# Altium schematic sheet Logic_controller.SchDoc(Logic_controller)
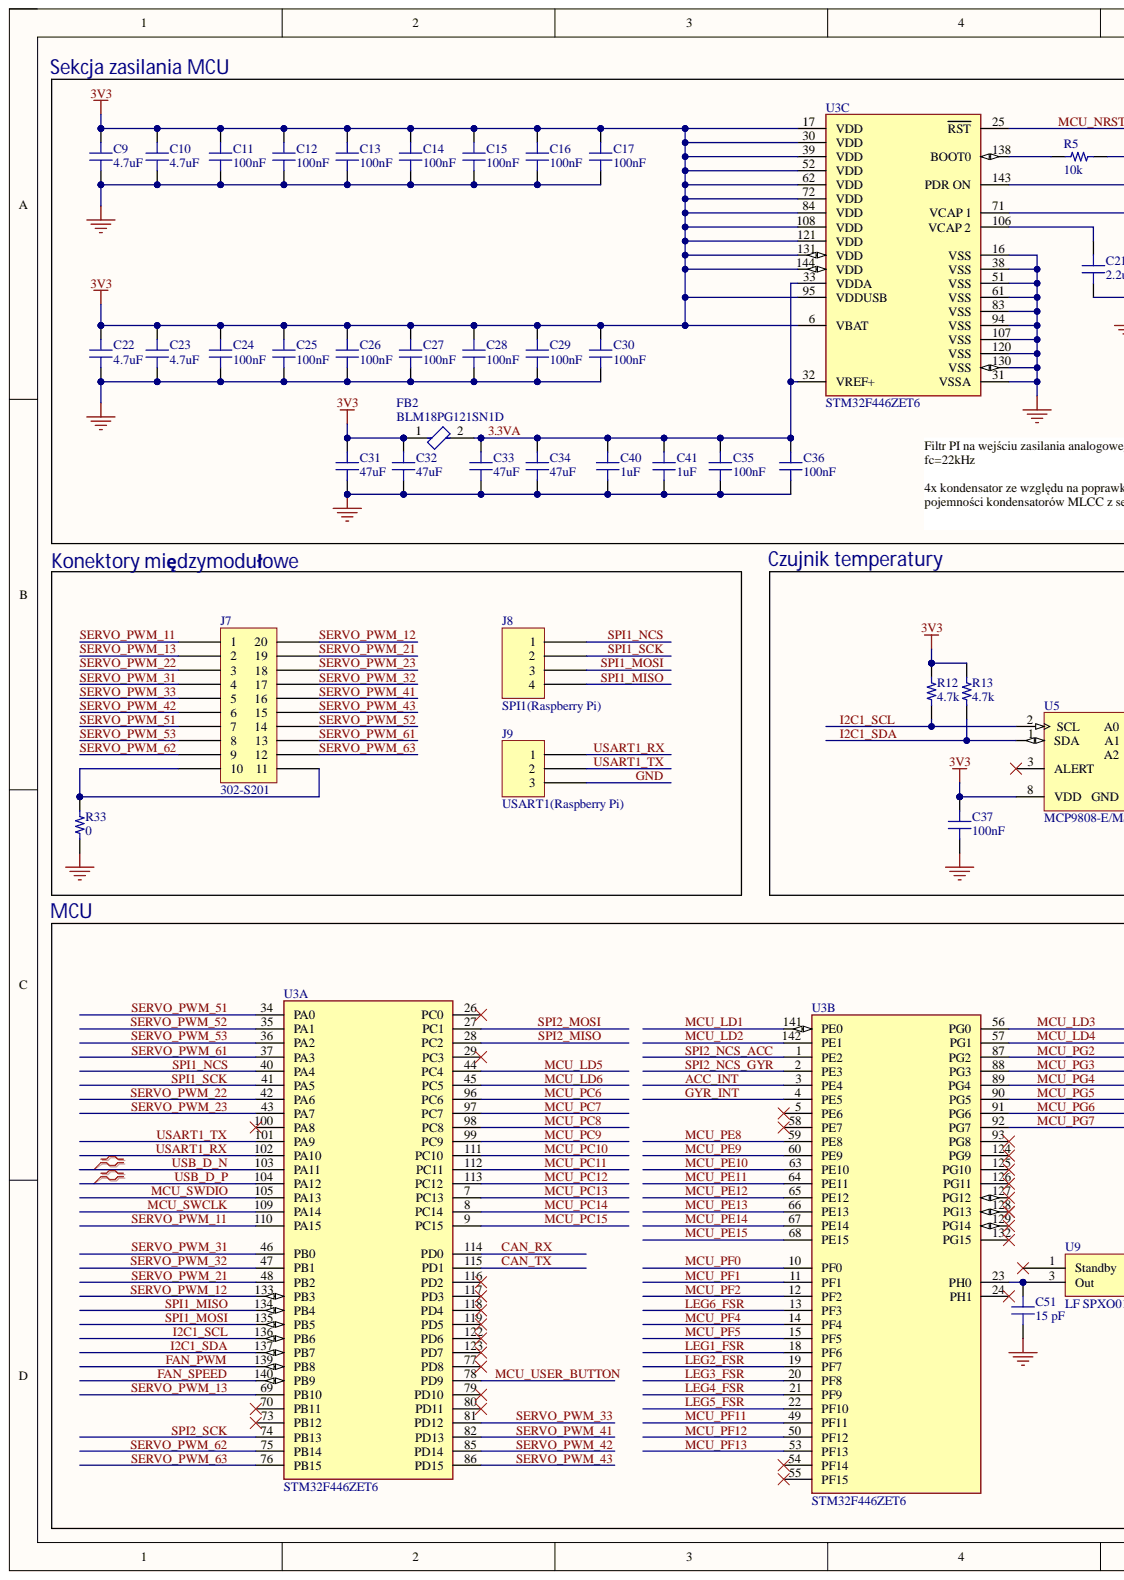
[diagram p0: Logic_controller.SchDoc, left]
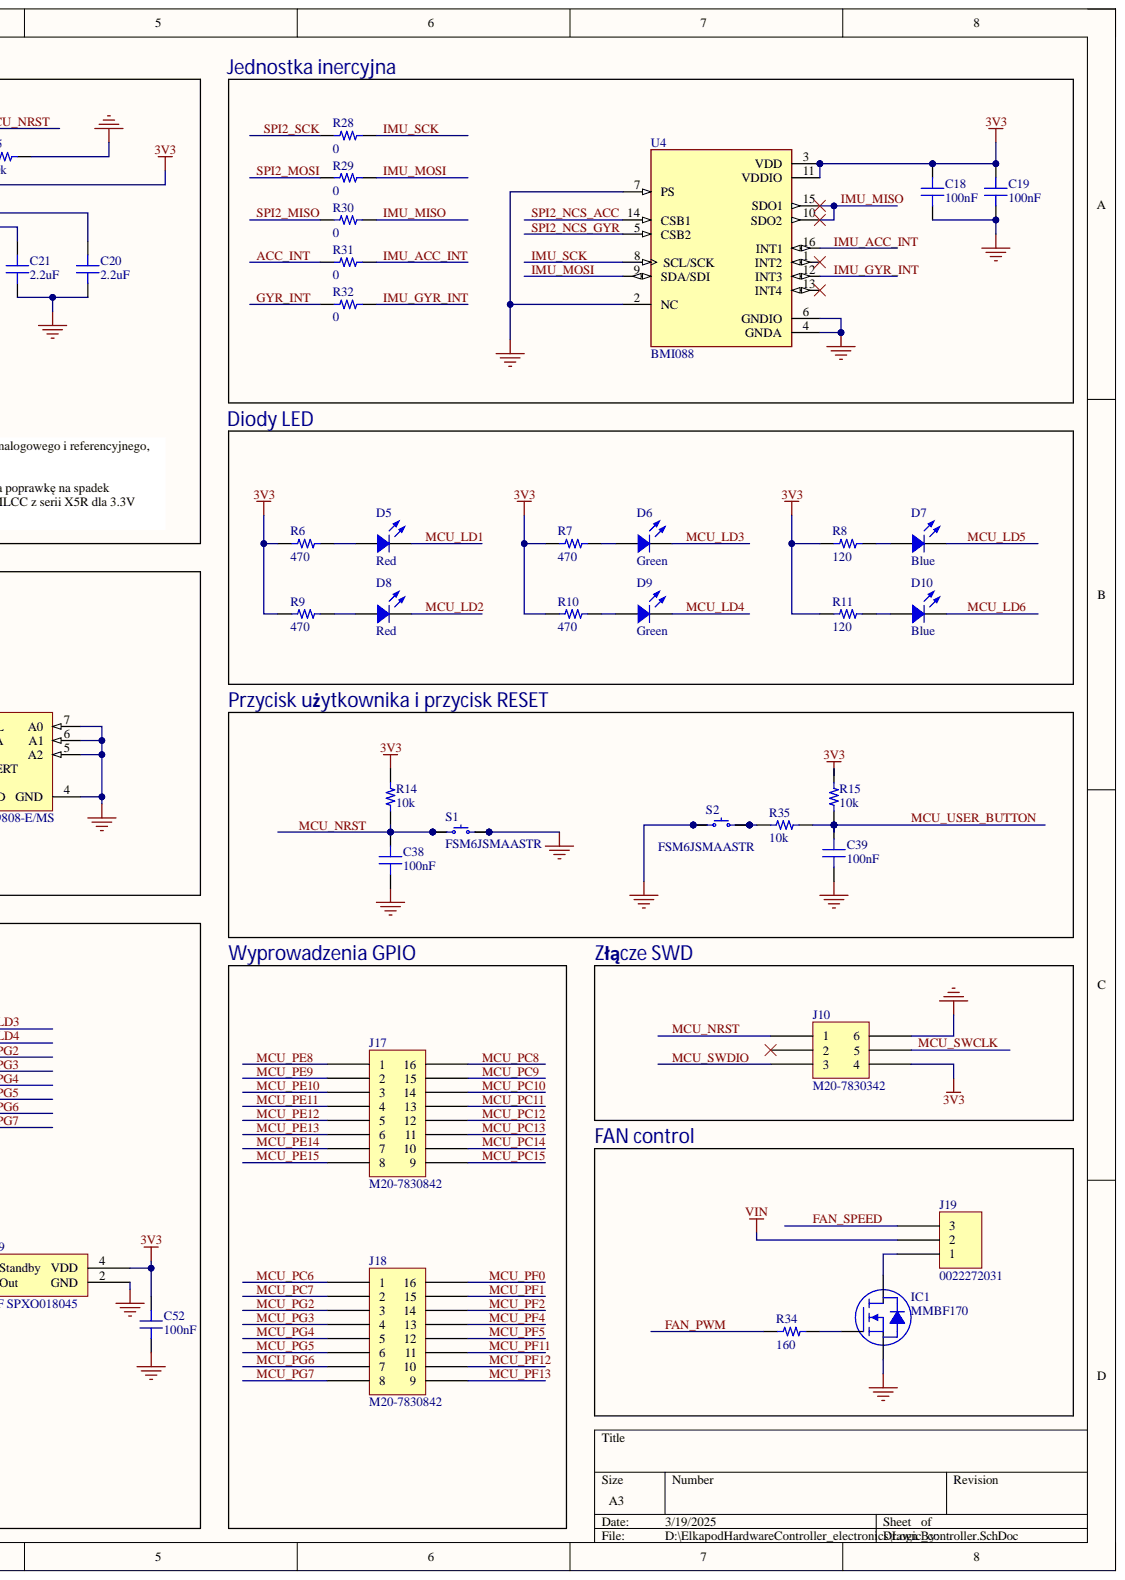
[diagram p1: Logic_controller.SchDoc, right]

## Parts
C9  Capacitance: 4.7uF  [CAP 0603_1608]  (hidden comment: 4.7 µF)
C10  Capacitance: 4.7uF  [CAP 0603_1608]  (hidden comment: 4.7 µF)
C11  Capacitance: 100nF  [CAP 0603_1608]  (hidden comment: 0.1 µF)
C12  Capacitance: 100nF  [CAP 0603_1608]  (hidden comment: 0.1 µF)
C13  Capacitance: 100nF  [CAP 0603_1608]  (hidden comment: 0.1 µF)
C14  Capacitance: 100nF  [CAP 0603_1608]  (hidden comment: 0.1 µF)
C15  Capacitance: 100nF  [CAP 0603_1608]  (hidden comment: 0.1 µF)
C16  Capacitance: 100nF  [CAP 0603_1608]  (hidden comment: 0.1 µF)
C17  Capacitance: 100nF  [CAP 0603_1608]  (hidden comment: 0.1 µF)
C18  Capacitance: 100nF  [CAP 0603_1608]  (hidden comment: 0.1 µF)
C19  Capacitance: 100nF  [CAP 0603_1608]  (hidden comment: 0.1 µF)
C20  Capacitance: 2.2uF  [CAP 0603_1608]  (hidden comment: 2.2 µF)
C21  Capacitance: 2.2uF  [CAP 0603_1608]  (hidden comment: 2.2 µF)
C22  Capacitance: 4.7uF  [CAP 0603_1608]  (hidden comment: 4.7 µF)
C23  Capacitance: 4.7uF  [CAP 0603_1608]  (hidden comment: 4.7 µF)
C24  Capacitance: 100nF  [CAP 0603_1608]  (hidden comment: 0.1 µF)
C25  Capacitance: 100nF  [CAP 0603_1608]  (hidden comment: 0.1 µF)
C26  Capacitance: 100nF  [CAP 0603_1608]  (hidden comment: 0.1 µF)
C27  Capacitance: 100nF  [CAP 0603_1608]  (hidden comment: 0.1 µF)
C28  Capacitance: 100nF  [CAP 0603_1608]  (hidden comment: 0.1 µF)
C29  Capacitance: 100nF  [CAP 0603_1608]  (hidden comment: 0.1 µF)
C30  Capacitance: 100nF  [CAP 0603_1608]  (hidden comment: 0.1 µF)
C31  Capacitance: 47uF  [MURATA GRM188 0603_1608]  (hidden comment: 47 µF)
C32  Capacitance: 47uF  [MURATA GRM188 0603_1608]  (hidden comment: 47 µF)
C33  Capacitance: 47uF  [MURATA GRM188 0603_1608]  (hidden comment: 47 µF)
C34  Capacitance: 47uF  [MURATA GRM188 0603_1608]  (hidden comment: 47 µF)
C35  Capacitance: 100nF  [CAP 0603_1608]  (hidden comment: 0.1 µF)
C36  Capacitance: 100nF  [CAP 0603_1608]  (hidden comment: 0.1 µF)
C37  Capacitance: 100nF  [CAP 0603_1608]  (hidden comment: 0.1 µF)
C38  Capacitance: 100nF  [CAP 0603_1608]  (hidden comment: 0.1 µF)
C39  Capacitance: 100nF  [CAP 0603_1608]  (hidden comment: 0.1 µF)
C40  Capacitance: 1uF  [CAP 0603_1608]  (hidden comment: 1 µF)
C41  Capacitance: 1uF  [CAP 0603_1608]  (hidden comment: 1 µF)
C51  15 pF  [CAP 0603_1608]
C52  Capacitance: 100nF  [CAP 0603_1608]  (hidden comment: 0.1 µF)
D5  Red  [LED 0805_2012 RED]
D6  Green  [LED 0805_2012 GREEN]
D7  Blue  [LED 0805_2012 BLUE]
D8  Red  [LED 0805_2012 RED]
D9  Green  [LED 0805_2012 GREEN]
D10  Blue  [LED 0805_2012 BLUE]
FB2  BLM18PG121SN1D  [FP-BLM18-0_15-t0_8-IPC_A]
IC1  MMBF170  [ON SEMI SOT-23-3]
J7  302-S201  [OST 302-S201]
J8  SPI1(Raspberry Pi)  [JST XH B4B-XH-A]
J9  USART1(Raspberry Pi)  [JST XH B3B-XH-A]
J10  M20-7830342  [HARWIN M20-7830345]
J17  M20-7830842  [HARWIN M20-7830845]
J18  M20-7830842  [HARWIN M20-7830845]
J19  0022272031  [MOLEX KK 0022272031]
R5  10k  [RES 0603_1608]
R6  470  [RES 0603_1608]
R7  470  [RES 0603_1608]
R8  120  [RES 0603_1608]
R9  470  [RES 0603_1608]
R10  470  [RES 0603_1608]
R11  120  [RES 0603_1608]
R12  4.7k  [RES 0603_1608]
R13  4.7k  [RES 0603_1608]
R14  10k  [RES 0603_1608]
R15  10k  [RES 0603_1608]
R28  0  [YAGEO RES 0603_1608]
R29  0  [YAGEO RES 0603_1608]
R30  0  [YAGEO RES 0603_1608]
R31  0  [YAGEO RES 0603_1608]
R32  0  [YAGEO RES 0603_1608]
R33  0  [YAGEO RES 0603_1608]
R34  160  [RES 0603_1608]
R35  10k  [RES 0603_1608]
S1  FSM6JSMAASTR  [TE FSM6JSMA]
S2  FSM6JSMAASTR  [TE FSM6JSMA]
U3  STM32F446ZET6  [FP-STM32F446ZET6-IPC_C]  (parts A,B,C)
U4  BMI088  [VFLGA-16-BMI088]
U5  MCP9808-E/MS  [MCHP-MSOP-MS8_L]
U9  LF SPXO018045  [LF SPXO018045]

## Nets
3V3: C9.2 C10.2 C11.2 C12.2 C13.2 C14.2 C15.2 C16.2 C17.2 C18.2 C19.2 C22.2 C23.2 C24.2 C25.2 C26.2 C27.2 C28.2 C29.2 C30.2 C31.2 C32.2 C37.2 C52.2 FB2.1 J10.4 R6.1 R7.1 R8.1 R9.1 R10.1 R11.1 R12.2 R13.2 R14.2 R15.2 U3.6(VBAT) U3.17(VDD) U3.30(VDD) U3.39(VDD) U3.52(VDD) U3.62(VDD) U3.72(VDD) U3.84(VDD) U3.95(VDDUSB) U3.108(VDD) U3.121(VDD) U3.131(VDD) U3.143(PDR_ON) U3.144(VDD) U4.3(VDD) U4.11(VDDIO) U5.8(VDD) U9.4(VDD)
VIN: J19.2
3.3VA: C33.2 C34.2 C35.2 C36.2 C40.2 C41.2 FB2.2 U3.32(VREF+) U3.33(VDDA)
ACC_INT: R31.1 U3.3(PE4)
CAN_RX: U3.114(PD0)
CAN_TX: U3.115(PD1)
FAN_PWM: R34.1 U3.139(PB8)
FAN_SPEED: J19.3 U3.140(PB9)
GND: C9.1 C10.1 C11.1 C12.1 C13.1 C14.1 C15.1 C16.1 C17.1 C18.1 C19.1 C20.1 C21.1 C22.1 C23.1 C24.1 C25.1 C26.1 C27.1 C28.1 C29.1 C30.1 C31.1 C32.1 C33.1 C34.1 C35.1 C36.1 C37.1 C38.1 C39.1 C40.1 C41.1 C51.1 C52.1 IC1.2 J9.3 J10.6 R5.2 R33.1 S1.3 S1.4 S2.1 S2.2 U3.16(VSS) U3.31(VSSA) U3.38(VSS) U3.51(VSS) U3.61(VSS) U3.83(VSS) U3.94(VSS) U3.107(VSS) U3.120(VSS) U3.130(VSS) U4.2(NC) U4.4(GNDA) U4.6(GNDIO) U4.7(PS) U5.4(GND) U5.5(A2) U5.6(A1) U5.7(A0) U9.2(GND)
GYR_INT: R32.1 U3.4(PE5)
I2C1_SCL: R12.1 U3.136(PB6) U5.2(SCL)
I2C1_SDA: R13.1 U3.137(PB7) U5.1(SDA)
IMU_ACC_INT: R31.2 U4.16(INT1)
IMU_GYR_INT: R32.2 U4.12(INT3)
IMU_MISO: R30.2 U4.10(SDO2) U4.15(SDO1)
IMU_MOSI: R29.2 U4.9(SDA/SDI)
IMU_SCK: R28.2 U4.8(SCL/SCK)
LEG1_FSR: U3.18(PF6)
LEG2_FSR: U3.19(PF7)
LEG3_FSR: U3.20(PF8)
LEG4_FSR: U3.21(PF9)
LEG5_FSR: U3.22(PF10)
LEG6_FSR: U3.13(PF3)
MCU_LD1: D5.2 U3.141(PE0)
MCU_LD2: D8.2 U3.142(PE1)
MCU_LD3: D6.2 U3.56(PG0)
MCU_LD4: D9.2 U3.57(PG1)
MCU_LD5: D7.2 U3.44(PC4)
MCU_LD6: D10.2 U3.45(PC5)
MCU_NRST: C38.2 J10.1 R14.1 S1.1 S1.2 U3.25(RST)
MCU_PC6: J18.1 U3.96(PC6)
MCU_PC7: J18.2 U3.97(PC7)
MCU_PC8: J17.16 U3.98(PC8)
MCU_PC9: J17.15 U3.99(PC9)
MCU_PC10: J17.14 U3.111(PC10)
MCU_PC11: J17.13 U3.112(PC11)
MCU_PC12: J17.12 U3.113(PC12)
MCU_PC13: J17.11 U3.7(PC13)
MCU_PC14: J17.10 U3.8(PC14)
MCU_PC15: J17.9 U3.9(PC15)
MCU_PE8: J17.1 U3.59(PE8)
MCU_PE9: J17.2 U3.60(PE9)
MCU_PE10: J17.3 U3.63(PE10)
MCU_PE11: J17.4 U3.64(PE11)
MCU_PE12: J17.5 U3.65(PE12)
MCU_PE13: J17.6 U3.66(PE13)
MCU_PE14: J17.7 U3.67(PE14)
MCU_PE15: J17.8 U3.68(PE15)
MCU_PF0: J18.16 U3.10(PF0)
MCU_PF1: J18.15 U3.11(PF1)
MCU_PF2: J18.14 U3.12(PF2)
MCU_PF4: J18.13 U3.14(PF4)
MCU_PF5: J18.12 U3.15(PF5)
MCU_PF11: J18.11 U3.49(PF11)
MCU_PF12: J18.10 U3.50(PF12)
MCU_PF13: J18.9 U3.53(PF13)
MCU_PG2: J18.3 U3.87(PG2)
MCU_PG3: J18.4 U3.88(PG3)
MCU_PG4: J18.5 U3.89(PG4)
MCU_PG5: J18.6 U3.90(PG5)
MCU_PG6: J18.7 U3.91(PG6)
MCU_PG7: J18.8 U3.92(PG7)
MCU_SWCLK: J10.5 U3.109(PA14)
MCU_SWDIO: J10.3 U3.105(PA13)
MCU_USER_BUTTON: C39.2 R15.1 R35.1 U3.78(PD9)
SERVO_PWM_11: J7.1 U3.110(PA15)
SERVO_PWM_12: J7.20 U3.133(PB3)
SERVO_PWM_13: J7.2 U3.69(PB10)
SERVO_PWM_21: J7.19 U3.48(PB2)
SERVO_PWM_22: J7.3 U3.42(PA6)
SERVO_PWM_23: J7.18 U3.43(PA7)
SERVO_PWM_31: J7.4 U3.46(PB0)
SERVO_PWM_32: J7.17 U3.47(PB1)
SERVO_PWM_33: J7.5 U3.81(PD12)
SERVO_PWM_41: J7.16 U3.82(PD13)
SERVO_PWM_42: J7.6 U3.85(PD14)
SERVO_PWM_43: J7.15 U3.86(PD15)
SERVO_PWM_51: J7.7 U3.34(PA0)
SERVO_PWM_52: J7.14 U3.35(PA1)
SERVO_PWM_53: J7.8 U3.36(PA2)
SERVO_PWM_61: J7.13 U3.37(PA3)
SERVO_PWM_62: J7.9 U3.75(PB14)
SERVO_PWM_63: J7.12 U3.76(PB15)
SPI1_MISO: J8.4 U3.134(PB4)
SPI1_MOSI: J8.3 U3.135(PB5)
SPI1_NCS: J8.1 U3.40(PA4)
SPI1_SCK: J8.2 U3.41(PA5)
SPI2_MISO: R30.1 U3.28(PC2)
SPI2_MOSI: R29.1 U3.27(PC1)
SPI2_NCS_ACC: U3.1(PE2) U4.14(CSB1)
SPI2_NCS_GYR: U3.2(PE3) U4.5(CSB2)
SPI2_SCK: R28.1 U3.74(PB13)
USART1_RX: J9.1 U3.102(PA10)
USART1_TX: J9.2 U3.101(PA9)
USB_D_N: U3.103(PA11)
USB_D_P: U3.104(PA12)
NetC20_2: C20.2 U3.71(VCAP_1)
NetC21_2: C21.2 U3.106(VCAP_2)
NetC51_2: C51.2 U3.23(PH0) U9.3(Out)
NetD5_1: D5.1 R6.2
NetD6_1: D6.1 R7.2
NetD7_1: D7.1 R8.2
NetD8_1: D8.1 R9.2
NetD9_1: D9.1 R10.2
NetD10_1: D10.1 R11.2
NetIC1_1: IC1.1 R34.2
NetIC1_3: IC1.3 J19.1
NetJ7_10: J7.10 J7.11 R33.2
NetR5_1: R5.1 U3.138(BOOT0)
NetR35_2: R35.2 S2.3 S2.4

Not connected: J10 2; U3 5(PE6) 24(PH1) 26(PC0) 29(PC3) 54(PF14) 55(PF15) 58(PE7) 70(PB11) 73(PB12) 77(PD8) 79(PD10) 80(PD11) 93(PG8) 100(PA8) 116(PD2) 117(PD3) 118(PD4) 119(PD5) 122(PD6) 123(PD7) 124(PG9) 125(PG10) 126(PG11) 127(PG12) 128(PG13) 129(PG14) 132(PG15); U4 1(INT2) 13(INT4); U5 3(ALERT); U9 1(Standby)

## Library data of the parts
C9 C10 C22 C23 = CL10A475MA8NQNC: CAP CER 4.7UF 25V X5R 0603; manufacturer: Samsung Electro-Mechanics; Database Table Name: Capacitors - Ceramic - 0603; Tolerance: ±20%; Applications: General Purpose; Component Kind: Standard; componentlink1description: Datasheet; componentlink1url: http://www.samsungsem.com/kr/support/product-search/mlcc/CL10A475MA8NQNC.jsp; componentlink2description: DigiKey Link; componentlink2url: https://www.digikey.com/en/products/detail/samsung-electro-mechanics/CL10A475MA8NQNC/3887561; Device Package: 0603 (1608 Metric); lastupdated: 09/02/2024 20:10:28; Library Name: Celestial Altium Library - altium_library.DbLib; Lifecycle Status: Active; Minimum Order: 1; Mounting Type: Surface Mount, MLCC; Operating Temperature: -55°C ~ 85°C; Package / Case: 0603 (1608 Metric); packaging: TapeAndReel; Part Number: CL10A475MA8NQNC; Part Status: Active; partid: 4111; price: 0.04; series: CL; Size / Dimension: 0.063" L x 0.031" W (1.60mm x 0.80mm); Supplier 1: DigiKey; Supplier Part Number 1: 1276-1903-1-ND; Temperature Coefficient: X5R; Thickness (Max): 0.035" (0.90mm); value: 4.7 µF; Voltage - Rated: 25V
C11-C19 C24-C30 C35-C39 C52 = CL10B104KA8NNNC: CAP CER 0.1UF 25V X7R 0603; manufacturer: Samsung Electro-Mechanics; Database Table Name: Capacitors - Ceramic - 0603; Tolerance: ±10%; Applications: General Purpose; Component Kind: Standard; componentlink1description: Datasheet; componentlink1url: http://www.samsungsem.com/kr/support/product-search/mlcc/CL10B104KA8NNNC.jsp; componentlink2description: DigiKey Link; componentlink2url: https://www.digikey.com/en/products/detail/samsung-electro-mechanics/CL10B104KA8NNNC/3886664; Device Package: 0603 (1608 Metric); lastupdated: 09/02/2024 20:10:20; Library Name: Celestial Altium Library - altium_library.DbLib; Lifecycle Status: Active; Minimum Order: 1; Mounting Type: Surface Mount, MLCC; Operating Temperature: -55°C ~ 125°C; Package / Case: 0603 (1608 Metric); packaging: TapeAndReel; Part Number: CL10B104KA8NNNC; Part Status: Active; partid: 9793; price: 0.01; series: CL; Size / Dimension: 0.063" L x 0.031" W (1.60mm x 0.80mm); Supplier 1: DigiKey; Supplier Part Number 1: 1276-1006-1-ND; Temperature Coefficient: X7R; Thickness (Max): 0.035" (0.90mm); value: 0.1 µF; Voltage - Rated: 25V
C20 = CC0603ZRY5V7BB225: CAP CER 2.2UF 16V Y5V 0603; manufacturer: Yageo; Database Table Name: Capacitors - Ceramic - 0603; Temperature Coefficient: Y5V (F); Tolerance: -20%, +80%; Applications: General Purpose; Component Kind: Standard; componentlink1description: Datasheet; componentlink1url: http://www.yageo.com/documents/recent/UPY-GPHC_Y5V_6.3V-to-50V_8.pdf; componentlink2description: DigiKey Link; componentlink2url: https://www.digikey.com/en/products/detail/yageo/CC0603ZRY5V7BB225/5883851; Device Package: 0603 (1608 Metric); lastupdated: 09/02/2024 20:10:30; Library Name: Celestial Altium Library - altium_library.DbLib; Lifecycle Status: Active; Minimum Order: 1; Mounting Type: Surface Mount, MLCC; Operating Temperature: -30°C ~ 85°C; Package / Case: 0603 (1608 Metric); packaging: TapeAndReel; Part Number: CC0603ZRY5V7BB225; Part Status: Active; partid: 2203; price: 0.01; series: CC; Size / Dimension: 0.063" L x 0.031" W (1.60mm x 0.80mm); Supplier 1: DigiKey; Supplier Part Number 1: 311-3416-1-ND; Thickness (Max): 0.035" (0.90mm); value: 2.2 µF; Voltage - Rated: 16V
C21 = CL10A225KO8NNNC: CAP CER 2.2UF 16V X5R 0603; manufacturer: Samsung Electro-Mechanics; Database Table Name: Capacitors - Ceramic - 0603; Tolerance: ±10%; Applications: General Purpose; Component Kind: Standard; componentlink1description: Datasheet; componentlink1url: http://www.samsungsem.com/kr/support/product-search/mlcc/CL10A225KO8NNNC.jsp; componentlink2description: DigiKey Link; componentlink2url: https://www.digikey.com/en/products/detail/samsung-electro-mechanics/CL10A225KO8NNNC/3886698; Device Package: 0603 (1608 Metric); lastupdated: 09/02/2024 20:10:26; Library Name: Celestial Altium Library - altium_library.DbLib; Lifecycle Status: Active; Minimum Order: 1; Mounting Type: Surface Mount, MLCC; Operating Temperature: -55°C ~ 85°C; Package / Case: 0603 (1608 Metric); packaging: TapeAndReel; Part Number: CL10A225KO8NNNC; Part Status: Active; partid: 5651; price: 0.01; series: CL; Size / Dimension: 0.063" L x 0.031" W (1.60mm x 0.80mm); Supplier 1: DigiKey; Supplier Part Number 1: 1276-1040-1-ND; Temperature Coefficient: X5R; Thickness (Max): 0.035" (0.90mm); value: 2.2 µF; Voltage - Rated: 16V
C31-C34 = GRM188R60J476ME15D: CAP CER 47UF 6.3V X5R 0603; manufacturer: Murata Electronics; Database Table Name: Capacitors - Ceramic - 0603; Tolerance: ±20%; Applications: General Purpose; Component Kind: Standard; componentlink1description: Datasheet; componentlink1url: https://www.murata.com/~/media/webrenewal/support/library/catalog/products/capacitor/mlcc/c02e.ashx?la=en-us; componentlink2description: DigiKey Link; componentlink2url: https://www.digikey.com/en/products/detail/murata-electronics/GRM188R60J476ME15D/5877410; Device Package: 0603 (1608 Metric); lastupdated: 20/07/2024 00:23:22; Library Name: Celestial Altium Library - altium_library.DbLib; Lifecycle Status: Active; Minimum Order: 1; Mounting Type: Surface Mount, MLCC; Operating Temperature: -55°C ~ 85°C; Package / Case: 0603 (1608 Metric); packaging: TapeAndReel; Part Number: GRM188R60J476ME15D; Part Status: Active; partid: 5468; price: 0.10; series: GRM; Size / Dimension: 0.063" L x 0.031" W (1.60mm x 0.80mm); Supplier 1: DigiKey; Supplier Part Number 1: 490-13247-1-ND; Temperature Coefficient: X5R; Thickness (Max): 0.039" (1.00mm); value: 47 µF; Voltage - Rated: 6.3V
C40 C41 = CL10B105KA8NNNC: CAP CER 1UF 25V X7R 0603; manufacturer: Samsung Electro-Mechanics; Database Table Name: Capacitors - Ceramic - 0603; Tolerance: ±10%; Applications: General Purpose; Component Kind: Standard; componentlink1description: Datasheet; componentlink1url: http://www.samsungsem.com/kr/support/product-search/mlcc/CL10B105KA8NNNC.jsp; componentlink2description: DigiKey Link; componentlink2url: https://www.digikey.com/en/products/detail/samsung-electro-mechanics/CL10B105KA8NNNC/3886842; Device Package: 0603 (1608 Metric); lastupdated: 09/02/2024 20:10:26; Library Name: Celestial Altium Library - altium_library.DbLib; Lifecycle Status: Active; Minimum Order: 1; Mounting Type: Surface Mount, MLCC; Operating Temperature: -55°C ~ 125°C; Package / Case: 0603 (1608 Metric); packaging: TapeAndReel; Part Number: CL10B105KA8NNNC; Part Status: Active; partid: 5552; price: 0.01; series: CL; Size / Dimension: 0.063" L x 0.031" W (1.60mm x 0.80mm); Supplier 1: DigiKey; Supplier Part Number 1: 1276-1184-1-ND; Temperature Coefficient: X7R; Thickness (Max): 0.035" (0.90mm); value: 1 µF; Voltage - Rated: 25V
C51 = 0603N150J500CT: CAP CER 15PF 50V C0G/NP0 0603; manufacturer: Walsin Technology Corporation; Database Table Name: Capacitors - Ceramic - 0603; Temperature Coefficient: C0G, NP0; Tolerance: ±5%; Applications: General Purpose; Capacitance: 15 pF; Component Kind: Standard; componentlink1description: Datasheet; componentlink1url: http://www.passivecomponent.com/wp-content/uploads/2018/10/MLCC.pdf; componentlink2description: DigiKey Link; componentlink2url: https://www.digikey.com/en/products/detail/walsin-technology-corporation/0603N150J500CT/9354984; Device Package: 0603 (1608 Metric); lastupdated: 09/02/2024 20:10:08; Library Name: Celestial Altium Library - altium_library.DbLib; Lifecycle Status: Active; Minimum Order: 1; Mounting Type: Surface Mount, MLCC; Operating Temperature: -55°C ~ 125°C; Package / Case: 0603 (1608 Metric); packaging: TapeAndReel; Part Number: 0603N150J500CT; Part Status: Active; partid: 17018; price: 0.01; series: -; Size / Dimension: 0.063" L x 0.031" W (1.60mm x 0.80mm); Supplier 1: DigiKey; Supplier Part Number 1: 1292-1487-1-ND; Thickness (Max): 0.034" (0.87mm); value: 15 pF; Voltage - Rated: 50V
D5 D8 = VFHV1112H-3BZ2B-TR: LED; Manufacturer: Stanley Electric Co; Configuration: Standard; Current - Test: 20mA; Database Table Name: LED - Indication; Lens Color: White; Lens Size: 1.30mm x 1.25mm; Lens Style: Rectangle with Flat Top; Millicandela Rating: 110mcd; Viewing Angle: 140°; Voltage - Forward (Vf) (Typ): 1.9V; Wavelength - Dominant: 615nm; Wavelength - Peak: 624nm; Color: Red; Component Kind: Standard; ComponentLink1Description: Datasheet; ComponentLink1URL: http://www.stanley-components.com/php/downloaddatafile.php?rp=0,VFHV1112H-3BZ2B-TR_e.pdf; ComponentLink2Description: DigiKey Link; ComponentLink2URL: http://digikey.com/product-detail/en/stanley-electric-co/VFHV1112H-3BZ2B-TR/404-1314-1-ND/9477319; Device Package: 0805 (2012 Metric); Height (Max): 0.90mm; LastUpdated: 03/06/2024 20:00:06; Library Name: Celestial Altium Library - altium_library.DbLib; Lifecycle Status: Active; Minimum Order: 1; Mounting Type: Surface Mount; Package / Case: 0805 (2012 Metric); Packaging: TapeAndReel; Part Number: VFHV1112H-3BZ2B-TR; Part Status: Active; PartId: 359; Price: 0.08; Series: -; Size / Dimension: 2.00mm L x 1.25mm W; Supplier 1: DigiKey; Supplier Part Number 1: 404-1314-1-ND; Value: Red
D6 D9 = QTLP630C4TR: LED GREEN CLEAR 0805 SMD; Manufacturer: Everlight Electronics Co Ltd; Configuration: Standard; Current - Test: 20mA; Database Table Name: LED - Indication; Lens Color: Colorless; Lens Size: 1.40mm x 1.25mm; Lens Style: Rectangle with Flat Top; Millicandela Rating: 10mcd; Viewing Angle: 140°; Voltage - Forward (Vf) (Typ): 2.1V; Wavelength - Dominant: 570nm; Wavelength - Peak: 565nm; Color: Green; Component Kind: Standard; ComponentLink1Description: Datasheet; ComponentLink1URL: //media.digikey.com/PDF/Data%20Sheets/Everlight%20PDFs/QTLP630-2.pdf; ComponentLink2Description: DigiKey Link; ComponentLink2URL: https://www.digikey.com/en/products/detail/everlight-electronics-co-ltd/QTLP630C4TR/2675902; Device Package: 0805 (2012 Metric); Height (Max): 1.20mm; LastUpdated: 03/06/2024 20:00:30; Lens Transparency: Clear; Library Name: Celestial Altium Library - altium_library.DbLib; Lifecycle Status: Active; Minimum Order: 1; Mounting Type: Surface Mount; Package / Case: 0805 (2012 Metric); Packaging: TapeAndReel; Part Number: QTLP630C4TR; Part Status: Active; PartId: 240; Price: 0.05; Series: -; Size / Dimension: 2.00mm L x 1.25mm W; Supplier 1: DigiKey; Supplier Device Package: 0805; Supplier Part Number 1: 1080-1411-1-ND; Value: Green
D7 D10 = APT2012PR54F/A-SC: LED BLUE DIFFUSED CHIP SMD; Manufacturer: Kingbright; Configuration: Standard; Current - Test: 20mA; Database Table Name: LED - Indication; Lens Color: Green; Lens Size: 1.20mm x 1.25mm; Lens Style: Rectangle with Flat Top; Millicandela Rating: 120mcd; Viewing Angle: 160°; Voltage - Forward (Vf) (Typ): 3.2V; Color: Blue; Component Kind: Standard; ComponentLink1Description: Datasheet; ComponentLink1URL: http://www.kingbrightusa.com/images/catalog/SPEC/APT2012PR54F-A-SC.pdf; ComponentLink2Description: DigiKey Link; ComponentLink2URL: http://digikey.com/product-detail/en/kingbright/APT2012PR54F-A-SC/754-2181-1-ND/7776823; Device Package: 0805 (2012 Metric); Height (Max): 0.85mm; LastUpdated: 03/06/2024 19:58:01; Lens Transparency: Diffused; Library Name: Celestial Altium Library - altium_library.DbLib; Lifecycle Status: Active; Minimum Order: 1; Mounting Type: Surface Mount; Package / Case: 0805 (2012 Metric); Packaging: TapeAndReel; Part Number: APT2012PR54F/A-SC; Part Status: Active; PartId: 758; Price: 0.27; Series: -; Size / Dimension: 2.00mm L x 1.25mm W; Supplier 1: DigiKey; Supplier Device Package: Chip LED; Supplier Part Number 1: 754-2181-1-ND; Value: Blue
FB2 = CMP-0686-00557-7: Chip Ferrite Bead, 0603, 120Ω @ 100MHz, 0.05Ω, 25%, 2A; DC Resistance (DCR): 50mR; Impedance: 120R; Material: Steel; Number of Circuits: 1; Shielding: Unshielded; Case Code (Imperial): 0603; Case Code (Metric): 1608; Case/Package: 0603; Component Kind: Standard; Core Material: Ferrite; Current Rating: 2A; DC Current: 2A; Depth: 0.8mm; Frequency: 100MHz; Height: 0.8mm; Lead Free: Lead Free; Length: 1.6mm; Library Name: Altium Content Vault; Max Current Rating: 2A; Max DC Current: 2A; Max Operating Temperature: 125°C; Min Operating Temperature: -55°C; Number of Lines: 1; Number of Pins: 2; Package Quantity: 1; Packaging: Tape and Reel; Radiation Hardening: No; REACH SVHC: No SVHC; RoHS Compliant: Yes; Termination: SMD/SMT; Test Frequency: 100MHz; Tolerance: 25%; Width: 0.8mm
IC1 = MMBF170: MOSFET N-CH 60V 500MA SOT-23; Manufacturer: ON Semiconductor; Current - Continuous Drain (Id) @ 25°C: 500mA (Ta); Database Table Name: MOSFET - Single - N-Ch; Drive Voltage (Max Rds On,  Min Rds On): 10V; FET Type: N-Channel; Input Capacitance (Ciss) (Max) @ Vds: 40pF @ 10V; Power Dissipation (Max): 300mW (Ta); Rds On (Max) @ Id, Vgs: 5Ohm @ 200mA, 10V; Vgs (Max): ±20V; Vgs(th) (Max) @ Id: 3V @ 1mA; Component Kind: Standard; ComponentLink1Description: Datasheet; ComponentLink1URL: https://www.onsemi.com/pub/Collateral/MMBF170-D.PDF; ComponentLink2Description: DigiKey Link; ComponentLink2URL: http://digikey.com/product-detail/en/on-semiconductor/MMBF170/MMBF170CT-ND/244295; Drain to Source Voltage (Vdss): 60V; LastUpdated: 03/06/2024 20:15:25; Library Name: Celestial Altium Library - altium_library.DbLib; Lifecycle Status: Active; Minimum Order: 1; Mounting Type: Surface Mount; Operating Temperature: -55°C ~ 150°C (TJ); Package / Case: TO-236-3, SC-59, SOT-23-3; Packaging: TapeAndReel; Part Number: MMBF170; Part Status: Active; Price: 0.07; Series: -; Supplier 1: DigiKey; Supplier Device Package: SOT-23; Supplier Part Number 1: MMBF170CT-ND; Technology: MOSFET (Metal Oxide)
J7 = 302-S201: CONN HEADER VERT 20POS 2.54MM; Manufacturer: On Shore Technology Inc.; Contact Length - Post: 0.122" (3.10mm); Current Rating (Amps): 3A; Database Table Name: Connectors - Rectangular Headers Male Pins; Material Flammability Rating: UL94 V-0; Number of Positions: 20; Number of Positions Loaded: All; Pitch - Mating: 0.100" (2.54mm); Shrouding: Shrouded - 4 Wall; Style: Board to Cable/Wire; Component Kind: Standard; ComponentLink1Description: Datasheet; ComponentLink1URL: http://www.on-shore.com/wp-content/uploads/2018/04/302-SXX1.pdf; ComponentLink2Description: DigiKey Link; ComponentLink2URL: https://www.digikey.com/en/products/detail/on-shore-technology-inc/302-S201/2794235; Connector Type: Header; Contact Finish - Mating: Gold; Contact Finish - Post: Gold; Contact Finish Thickness - Mating: Flash; Contact Material: Brass; Contact Shape: Square; Contact Type: Male Pin; Fastening Type: Push-Pull; Features: Keying Slot; Insulation Color: Black; Insulation Height: 0.358" (9.10mm); Insulation Material: Polyester, Glass Filled; LastUpdated: 14/08/2022 17:03:46; Library Name: Celestial Altium Library - altium_library.DbLib; Lifecycle Status: Active; Minimum Order: 1; Mounting Type: Through Hole; Number of Rows: 2; Operating Temperature: -40°C ~ 105°C; Packaging: Tray; Part Number: 302-S201; Part Status: Active; PartId: 155; Price: 0.19; Row Spacing - Mating: 0.100" (2.54mm); Series: 302; Supplier 1: DigiKey; Supplier Part Number 1: ED10524-ND; Termination: Solder
J8 = B4B-XH-A(LF)(SN): CONN HEADER VERT 4POS 2.5MM; Manufacturer: JST Sales America Inc.; Contact Length - Post: 0.134" (3.40mm); Current Rating (Amps): 3A; Database Table Name: Connectors - Rectangular Headers Male Pins; Material Flammability Rating: UL94 V-0; Number of Positions: 4; Number of Positions Loaded: All; Pitch - Mating: 0.098" (2.50mm); Shrouding: Shrouded - 4 Wall; Style: Board to Cable/Wire; Voltage Rating: 250V; Component Kind: Standard; ComponentLink1Description: Datasheet; ComponentLink1URL: http://www.jst-mfg.com/product/pdf/eng/eXH.pdf; ComponentLink2Description: DigiKey Link; ComponentLink2URL: http://digikey.com/product-detail/en/jst-sales-america-inc/B4B-XH-A-LF-SN/455-2249-ND/1651047; Connector Type: Header; Contact Finish - Mating: Tin; Contact Finish - Post: Tin; Contact Material: Brass; Contact Shape: Square; Contact Type: Male Pin; Fastening Type: Detent Lock; Insulation Color: Natural; Insulation Height: 0.276" (7.00mm); Insulation Material: Polyamide (PA66), Nylon 6/6; LastUpdated: 12/01/2020 23:46:34; Library Name: Celestial Altium Library - altium_library.DbLib; Lifecycle Status: Active; Minimum Order: 1; Mounting Type: Through Hole; Number of Rows: 1; Operating Temperature: -25°C ~ 85°C; Packaging: Bulk; Part Number: B4B-XH-A(LF)(SN); Part Status: Active; PartId: 69; Price: 0.21; Series: XH; Supplier 1: DigiKey; Supplier Part Number 1: 455-2249-ND; Termination: Press-Fit, Solder
J9 = B3B-XH-A(LF)(SN): CONN HEADER VERT 3POS 2.5MM; Manufacturer: JST Sales America Inc.; Contact Length - Post: 0.134" (3.40mm); Current Rating (Amps): 3A; Database Table Name: Connectors - Rectangular Headers Male Pins; Material Flammability Rating: UL94 V-0; Number of Positions: 3; Number of Positions Loaded: All; Pitch - Mating: 0.098" (2.50mm); Shrouding: Shrouded - 4 Wall; Style: Board to Cable/Wire; Voltage Rating: 250V; Component Kind: Standard; ComponentLink1Description: Datasheet; ComponentLink1URL: http://www.jst-mfg.com/product/pdf/eng/eXH.pdf; ComponentLink2Description: DigiKey Link; ComponentLink2URL: http://digikey.com/product-detail/en/jst-sales-america-inc/B3B-XH-A-LF-SN/455-2248-ND/1651046; Connector Type: Header; Contact Finish - Mating: Tin; Contact Finish - Post: Tin; Contact Material: Brass; Contact Shape: Square; Contact Type: Male Pin; Fastening Type: Detent Lock; Insulation Color: Natural; Insulation Height: 0.276" (7.00mm); Insulation Material: Polyamide (PA66), Nylon 6/6; LastUpdated: 12/01/2020 23:46:22; Library Name: Celestial Altium Library - altium_library.DbLib; Lifecycle Status: Active; Minimum Order: 1; Mounting Type: Through Hole; Number of Rows: 1; Operating Temperature: -25°C ~ 85°C; Packaging: Bulk; Part Number: B3B-XH-A(LF)(SN); Part Status: Active; PartId: 68; Price: 0.19; Series: XH; Supplier 1: DigiKey; Supplier Part Number 1: 455-2248-ND; Termination: Press-Fit, Solder
J10 = M20-7830342: CONN RCPT 6POS 0.1 GOLD PCB; Manufacturer: Harwin Inc.; Connector Type: Receptacle; Contact Length - Post: 0.118" (3.00mm); Current Rating (Amps): 3A; Database Table Name: Connectors - Rectangular Headers Female Sockets; Mated Stacking Heights: 11.04mm, 12.63mm; Material Flammability Rating: UL94 V-0; Number of Positions: 6; Number of Positions Loaded: All; Pitch - Mating: 0.100" (2.54mm); Style: Board to Board or Cable; Component Kind: Standard; ComponentLink1Description: Datasheet; ComponentLink1URL: https://cdn.harwin.com/pdfs/Harwin_PC_Connectors_M20.pdf; ComponentLink2Description: DigiKey Link; ComponentLink2URL: https://www.digikey.com/en/products/detail/harwin-inc/M20-7830342/3727749; Contact Finish - Mating: Gold; Contact Finish - Post: Tin; Contact Finish Thickness - Mating: Flash; Contact Type: Female Socket; Fastening Type: Push-Pull; Insulation Color: Black; Insulation Height: 0.335" (8.51mm); LastUpdated: 21/09/2022 19:03:32; Library Name: Celestial Altium Library - altium_library.DbLib; Lifecycle Status: Active; Minimum Order: 1; Mounting Type: Through Hole; Number of Rows: 2; Operating Temperature: -40°C ~ 105°C; Packaging: Tube; Part Number: M20-7830342; Part Status: Active; Price: 0.67; Row Spacing - Mating: 0.100" (2.54mm); Series: M20; Supplier 1: DigiKey; Supplier Part Number 1: 952-1780-ND; Termination: Solder
J17 J18 = M20-7830842: CONN RCPT 16POS 0.1 GOLD PCB; Manufacturer: Harwin Inc.; Connector Type: Receptacle; Contact Length - Post: 0.118" (3.00mm); Current Rating (Amps): 3A; Database Table Name: Connectors - Rectangular Headers Female Sockets; Mated Stacking Heights: 11.04mm, 12.63mm; Material Flammability Rating: UL94 V-0; Number of Positions: 16; Number of Positions Loaded: All; Pitch - Mating: 0.100" (2.54mm); Style: Board to Board or Cable; Component Kind: Standard; ComponentLink1Description: Datasheet; ComponentLink1URL: https://cdn.harwin.com/pdfs/Harwin_PC_Connectors_M20.pdf; ComponentLink2Description: DigiKey Link; ComponentLink2URL: https://www.digikey.com/en/products/detail/harwin-inc/M20-7830842/3727786; Contact Finish - Mating: Gold; Contact Finish - Post: Tin; Contact Finish Thickness - Mating: Flash; Contact Type: Female Socket; Fastening Type: Push-Pull; Insulation Color: Black; Insulation Height: 0.335" (8.51mm); LastUpdated: 21/09/2022 19:06:04; Library Name: Celestial Altium Library - altium_library.DbLib; Lifecycle Status: Active; Minimum Order: 1; Mounting Type: Through Hole; Number of Rows: 2; Operating Temperature: -40°C ~ 105°C; Packaging: Tube; Part Number: M20-7830842; Part Status: Active; Price: 1.17; Row Spacing - Mating: 0.100" (2.54mm); Series: M20; Supplier 1: DigiKey; Supplier Part Number 1: 952-1819-ND; Termination: Solder
J19 = 0022272031: CONN HEADER VERT 3POS 2.54MM; Manufacturer: Molex; Contact Length - Mating: 0.295" (7.49mm); Contact Length - Post: 0.140" (3.56mm); Database Table Name: Connectors - Rectangular Headers Male Pins; Material Flammability Rating: UL94 V-0; Number of Positions: 3; Number of Positions Loaded: All; Overall Contact Length: 0.560" (14.22mm); Pitch - Mating: 0.100" (2.54mm); Shrouding: Shrouded - 1 Wall; Style: Board to Cable/Wire; Component Kind: Standard; ComponentLink1Description: Datasheet; ComponentLink1URL: https://www.molex.com/pdm_docs/sd/022272031_sd.pdf; ComponentLink2Description: DigiKey Link; ComponentLink2URL: http://digikey.com/product-detail/en/molex/0022272031/WM4112-ND/1130578; Connector Type: Header; Contact Finish - Mating: Tin; Contact Finish - Post: Tin; Contact Finish Thickness - Mating: 100.0µin (2.54µm); Contact Material: Brass; Contact Shape: Square; Contact Type: Male Pin; Fastening Type: Friction Lock; Insulation Color: Natural; Insulation Height: 0.461" (11.70mm); Insulation Material: Polyamide (PA), Nylon; LastUpdated: 12/01/2020 23:51:54; Library Name: Celestial Altium Library - altium_library.DbLib; Lifecycle Status: Active; Minimum Order: 1; Mounting Type: Through Hole; Number of Rows: 1; Packaging: Bulk; Part Number: 0022272031; Part Status: Active; PartId: 77; Price: 0.37; Series: KK 6410; Supplier 1: DigiKey; Supplier Part Number 1: WM4112-ND; Termination: Solder
R5 R14 R15 R35 = CRCW060310K0FKTA: RES SMD 10K OHM 1% 1/10W 0603; Manufacturer: Vishay Dale; Database Table Name: Resistors - Surface Mount - 0603; Power (Watts): 0.1W, 1/10W; Resistance: 10 kOhms; Temperature Coefficient: ±100ppm/°C; Component Kind: Standard; ComponentLink1Description: Datasheet; ComponentLink1URL: http://www.vishay.com/docs/20008/dcrcw.pdf; ComponentLink2Description: DigiKey Link; ComponentLink2URL: https://www.digikey.com/en/products/detail/vishay-dale/CRCW060310K0FKTA/1221625; Composition: Thick Film; Device Package: 0603 (1608 Metric); Features: Automotive AEC-Q200; Height - Seated (Max): 0.020" (0.50mm); LastUpdated: 12/07/2023 19:33:59; Library Name: Celestial Altium Library - altium_library.DbLib; Lifecycle Status: Active; Minimum Order: 1; Number of Terminations: 2; Operating Temperature: -55°C ~ 155°C; Package / Case: 0603 (1608 Metric); Packaging: TapeAndReel; Part Number: CRCW060310K0FKTA; Part Status: Active; PartId: 9687; Price: 0.01; Ratings: AEC-Q200; Series: CRCW; Size / Dimension: 0.063" L x 0.033" W (1.60mm x 0.85mm); Supplier 1: DigiKey; Supplier Device Package: 0603; Supplier Part Number 1: 541-2980-1-ND; Tolerance: ±1%; Value: 10k
R6 R7 R9 R10 = WR06X4700FTL: RES 470 OHM 1% 1/10W 0603; Manufacturer: Walsin Technology Corporation; Database Table Name: Resistors - Surface Mount - 0603; Power (Watts): 0.1W, 1/10W; Resistance: 470 Ohms; Temperature Coefficient: ±100ppm/°C; Component Kind: Standard; ComponentLink1Description: Datasheet; ComponentLink1URL: https://www.passivecomponent.com/wp-content/uploads/chipR/ASC_WR.pdf; ComponentLink2Description: DigiKey Link; ComponentLink2URL: https://www.digikey.com/en/products/detail/walsin-technology-corporation/WR06X4700FTL/13240646; Composition: Thick Film; Device Package: 0603 (1608 Metric); Height - Seated (Max): 0.024" (0.60mm); LastUpdated: 09/02/2024 20:41:18; Library Name: Celestial Altium Library - altium_library.DbLib; Lifecycle Status: Active; Minimum Order: 1; Number of Terminations: 2; Operating Temperature: -55°C ~ 155°C; Package / Case: 0603 (1608 Metric); Packaging: CutTape; Part Number: WR06X4700FTL; Part Status: Active; PartId: 61976; Price: 0.01; Series: WR; Size / Dimension: 0.063" L x 0.031" W (1.60mm x 0.80mm); Supplier 1: DigiKey; Supplier Device Package: 0603; Supplier Part Number 1: 1292-WR06X4700FTLCT-ND; Tolerance: ±1%; Value: 470
R8 R11 = ERJ-3GEYJ121V: RES SMD 120 OHM 5% 1/10W 0603; Manufacturer: Panasonic Electronic Components; Database Table Name: Resistors - Surface Mount - 0603; Power (Watts): 0.1W, 1/10W; Resistance: 120 Ohms; Temperature Coefficient: ±200ppm/°C; Component Kind: Standard; ComponentLink1Description: Datasheet; ComponentLink1URL: http://industrial.panasonic.com/www-cgi/jvcr13pz.cgi?E+PZ+3+AOA0001+ERJ3GEYJ121V+7+WW; ComponentLink2Description: DigiKey Link; ComponentLink2URL: https://www.digikey.com/en/products/detail/panasonic-electronic-components/ERJ-3GEYJ121V/135671; Composition: Thick Film; Device Package: 0603 (1608 Metric); Features: Automotive AEC-Q200; Height - Seated (Max): 0.022" (0.55mm); LastUpdated: 09/02/2024 20:42:41; Library Name: Celestial Altium Library - altium_library.DbLib; Lifecycle Status: Active; Minimum Order: 1; Number of Terminations: 2; Operating Temperature: -55°C ~ 155°C; Package / Case: 0603 (1608 Metric); Packaging: TapeAndReel; Part Number: ERJ-3GEYJ121V; Part Status: Active; PartId: 19208; Price: 0.01; Ratings: AEC-Q200; Series: ERJ-3G; Size / Dimension: 0.063" L x 0.031" W (1.60mm x 0.80mm); Supplier 1: DigiKey; Supplier Device Package: 0603; Supplier Part Number 1: P120GCT-ND; Tolerance: ±5%; Value: 120
R12 R13 = CRCW06034K70FKTA: RES SMD 4.7K OHM 1% 1/10W 0603; Manufacturer: Vishay Dale; Database Table Name: Resistors - Surface Mount - 0603; Power (Watts): 0.1W, 1/10W; Resistance: 4.7 kOhms; Temperature Coefficient: ±100ppm/°C; Component Kind: Standard; ComponentLink1Description: Datasheet; ComponentLink1URL: http://www.vishay.com/docs/20008/dcrcw.pdf; ComponentLink2Description: DigiKey Link; ComponentLink2URL: https://www.digikey.com/en/products/detail/vishay-dale/CRCW06034K70FKTA/1222150; Composition: Thick Film; Device Package: 0603 (1608 Metric); Features: Automotive AEC-Q200; Height - Seated (Max): 0.020" (0.50mm); LastUpdated: 09/02/2024 20:42:16; Library Name: Celestial Altium Library - altium_library.DbLib; Lifecycle Status: Active; Minimum Order: 1; Number of Terminations: 2; Operating Temperature: -55°C ~ 155°C; Package / Case: 0603 (1608 Metric); Packaging: TapeAndReel; Part Number: CRCW06034K70FKTA; Part Status: Active; PartId: 23863; Price: 0.01; Ratings: AEC-Q200; Series: CRCW; Size / Dimension: 0.063" L x 0.033" W (1.60mm x 0.85mm); Supplier 1: DigiKey; Supplier Device Package: 0603; Supplier Part Number 1: 541-3004-1-ND; Tolerance: ±1%; Value: 4.7k
R28-R33 = AA0603JR-070RL: RES SMD 0 OHM JUMPER 1/10W 0603; Manufacturer: Yageo; Database Table Name: Resistors - Surface Mount - 0603; Power (Watts): 0.1W, 1/10W; Resistance: 0 Ohms; Component Kind: Standard; ComponentLink1Description: Datasheet; ComponentLink1URL: https://www.yageo.com/upload/media/product/productsearch/datasheet/rchip/PYu-AA_51_RoHS_L_3.pdf; ComponentLink2Description: DigiKey Link; ComponentLink2URL: https://www.digikey.com/en/products/detail/yageo/AA0603JR-070RL/5889486; Composition: Thick Film; Device Package: 0603 (1608 Metric); Features: Anti-Sulfur, Automotive AEC-Q200, Moisture Resistant; Height - Seated (Max): 0.022" (0.55mm); LastUpdated: 25/07/2024 09:19:14; Library Name: Celestial Altium Library - altium_library.DbLib; Lifecycle Status: Active; Minimum Order: 1; Number of Terminations: 2; Operating Temperature: -55°C ~ 155°C; Package / Case: 0603 (1608 Metric); Packaging: TapeAndReel; Part Number: AA0603JR-070RL; Part Status: Active; PartId: 64274; Price: 0.01; Ratings: AEC-Q200; Series: AA; Size / Dimension: 0.063" L x 0.031" W (1.60mm x 0.80mm); Supplier 1: DigiKey; Supplier Device Package: 0603; Supplier Part Number 1: 13-AA0603JR-070RLCT-ND; Tolerance: Jumper; Value: 0
R34 = CR0603-FX-1600ELF: RES SMD 160 OHM 1% 1/10W 0603; Manufacturer: Bourns Inc.; Database Table Name: Resistors - Surface Mount - 0603; Power (Watts): 0.1W, 1/10W; Resistance: 160 Ohms; Temperature Coefficient: ±100ppm/°C; Component Kind: Standard; ComponentLink1Description: Datasheet; ComponentLink1URL: https://www.bourns.com/docs/Product-Datasheets/CHPREZTR.pdf; ComponentLink2Description: DigiKey Link; ComponentLink2URL: https://www.digikey.com/en/products/detail/bourns-inc/CR0603-FX-1600ELF/3783761; Composition: Thick Film; Device Package: 0603 (1608 Metric); Features: Moisture Resistant; Height - Seated (Max): 0.022" (0.55mm); LastUpdated: 09/02/2024 20:43:30; Library Name: Celestial Altium Library - altium_library.DbLib; Lifecycle Status: Active; Minimum Order: 1; Number of Terminations: 2; Operating Temperature: -55°C ~ 155°C; Package / Case: 0603 (1608 Metric); Packaging: CutTape; Part Number: CR0603-FX-1600ELF; Part Status: Active; PartId: 6436; Price: 0.01; Series: CR0603; Size / Dimension: 0.063" L x 0.031" W (1.60mm x 0.80mm); Supplier 1: DigiKey; Supplier Device Package: 0603; Supplier Part Number 1: CR0603-FX-1600ELFCT-ND; Tolerance: ±1%; Value: 160
S1 S2 = FSM6JSMAASTR: SWITCH TACTILE SPST-NO 0.05A 24V; Manufacturer: TE Connectivity ALCOSWITCH Switches; Actuator Height off PCB, Vertical: 7.00mm; Actuator Orientation: Top Actuated; Actuator Type: Standard; Contact Rating @ Voltage: 0.05A @ 24VDC; Database Table Name: Switches - Tactile; Illumination: Non-Illuminated; Operating Force: 260gf; Outline: 6.00mm x 6.00mm; Switch Function: Off-Mom; Circuit: SPST-NO; Component Kind: Standard; ComponentLink1Description: Datasheet; ComponentLink1URL: https://www.te.com/commerce/DocumentDelivery/DDEController?Action=srchrtrv&DocNm=1308111-1_SWITCHES_CORE_PROGRAM_CATALOG&DocType=CS&DocLang=English; ComponentLink2Description: DigiKey Link; ComponentLink2URL: https://www.digikey.com/en/products/detail/te-connectivity-alcoswitch-switches/FSM6JSMAASTR/5343804; LastUpdated: 03/06/2024 20:19:31; Library Name: Celestial Altium Library - altium_library.DbLib; Lifecycle Status: Active; Minimum Order: 1; Mounting Type: Surface Mount; Operating Temperature: -40°C ~ 105°C; Packaging: TapeAndReel; Part Number: FSM6JSMAASTR; Part Status: Active; Price: 0.30; Series: FSMJSMA; Supplier 1: DigiKey; Supplier Part Number 1: 450-2138-1-ND; Termination Style: Gull Wing
U3 = CMP-12105-000067-1: IC MCU 32BIT 512KB FLASH 144LQFP; Manufacturer: STMicroelectronics; Core Architecture: Arm Cortex-M4; Data Bus Width: 32bits; Frequency: 180MHz; Interface: I2C,SPI,UART,USART,EBI/EMI,CAN,USB,LIN,IrDA,I2S,SMBus,Other; Max Junction Temp: +105°C; Max Supply Voltage: 3.6V; Memory Size: 512KBytes; Min Supply Voltage: 1.7V; Number Of A/D Converters: 3; Number Of Adc Channels: 24; Number Of Cores: 1; Number Of I2C Channels: 4; Number Of I/Os: 114; Number Of Pwm Channels: 32; Number Of Timers/Counters: 14; Number Of Uart Channels: 6; Number Of Usb Channels: 2; Ram Size: 128KBytes; Standoff Height: 0.05mm; Ambient Temperature Range High: +85°C; Ambient Temperature Range Low: -40°C; Automotive: No; Category: IC; Component Kind: Standard; ComponentLink2Description: Datasheet; ComponentLink2URL: https://www.st.com/content/ccc/resource/technical/document/datasheet/65/cb/75/50/53/d6/48/24/DM00141306.pdf/files/DM00141306.pdf/jcr:content/translations/en.DM00141306.pdf; Device Class L1: Integrated Circuits (ICs); Device Class L2: Embedded Processors and Controllers; Device Class L3: Microcontrollers; Digikey Description: IC MCU 32BIT 512KB FLASH 144LQFP; Height: 1.6mm; Lead Free: Yes; Library Name: Components - 23.08.24 - 12.21.IntLib; Manufacturer Part Number: STM32F446ZET6; Memory Type: FLASH; Mouser Description: 32 Bit Microcontroller, High Performance, Arm Cortex-M4, 180 Mhz, 512 Kb, 128 Kb, 144, Lqfp; Number Of Spi Channels: 4; Octopart: https://octopart.com/stm32f446zet6-stmicroelectronics-52642927; Package: LQFP144; RoHS: TRUE; Temperature: -40°C to +105°C; Temperature Range High: +105°C; Temperature Range Low: -40°C
U4 = BMI088: High-performance 6-axis inertial sensor (accelerometer + gyroscope) <a href="https://pricing.snapeda.com/parts/BMI088/Bosch%20Sensortec/view-part?ref=eda">Check prices</a>; Availability: Unavailable; Component Kind: Standard; Library Name: My_integrated_circuits.IntLib; MP: BMI088; Package: None; Price: None
U5 = CMP-0192-00344-1: + / - 0.5 degC Maximum Accuracy Digital Temperature Sensor, 400 uA, 2.7 to 5.5 V, -40 to 125 degC, 8-pin MSOP (MS8), Tube; Manufacturer: Microchip; Component Kind: Standard; ComponentLink1Description: Manufacturer URL; ComponentLink1URL: http://www.microchip.com; ComponentLink2Description: Datasheet; ComponentLink2URL: http://ww1.microchip.com/downloads/en/DeviceDoc/25095A.pdf; ComponentLink3Description: Package Specification; ComponentLink3URL: http://www.microchip.com/stellent/groups/techpub_sg/documents/packagingspec/en012702.pdf; ComponentLink4Description: Product URL; ComponentLink4URL: http://www.microchip.com/MCP9808; DatasheetVersion: Rev. A; Library Name: Altium Content Vault; Mounting Technology: Surface Mount; PackageDescription: 8-Lead Plastic Micro Small Outline Package, 3 x 3 mm Body, 0.65 mm Pitch; PackageReference: MSOP-MS8; PackageVersion: C04-111C; PartNumber: MCP9808-E/MS
U9 = LF SPXO018045: Generator: kwarcowy; 8MHz; SMD; 3,3V; ±50ppm; 0÷70°C; 7,2x5,2x1,8mm; Component Kind: Standard; Library Name: My_integrated_circuits.IntLib
